# Altium schematic sheet Sheet1.SchDoc
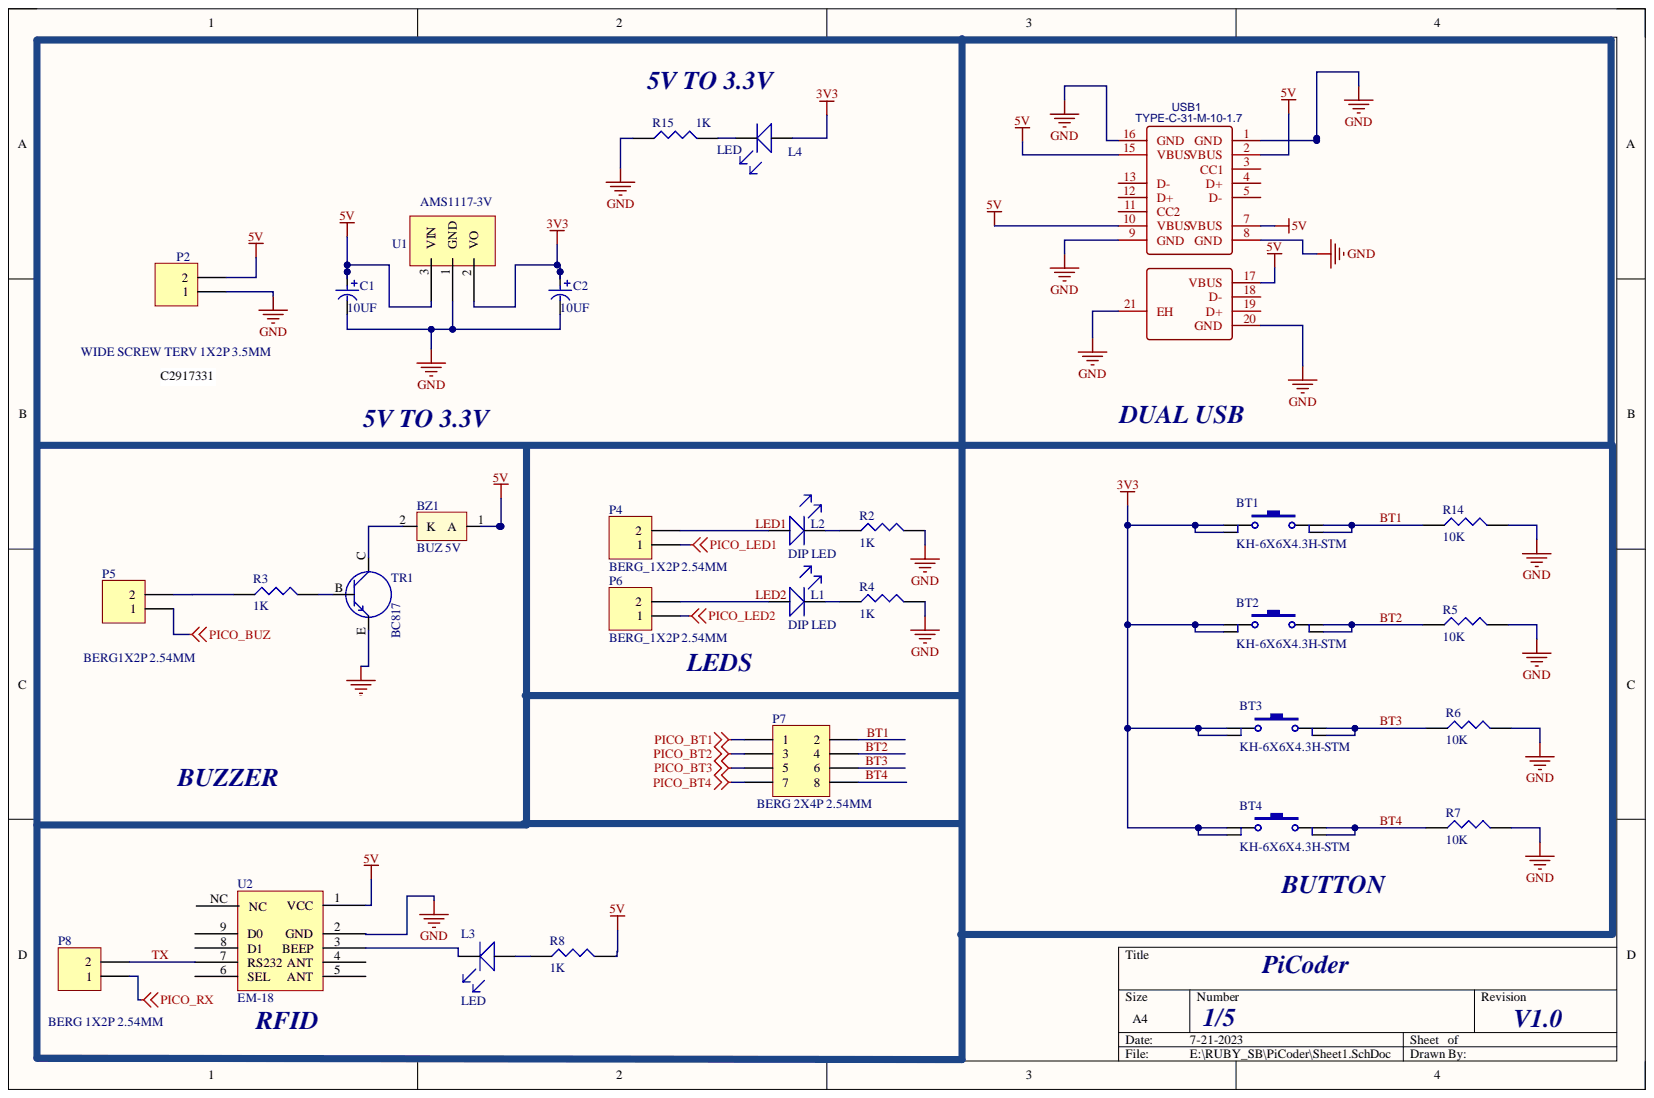
[diagram p0: Sheet1.SchDoc]

## Parts
BT1  KH-6X6X4.3H-STM  [SW_SMD_6X6MM]
BT2  KH-6X6X4.3H-STM  [SW_SMD_6X6MM]
BT3  KH-6X6X4.3H-STM  [SW_SMD_6X6MM]
BT4  KH-6X6X4.3H-STM  [SW_SMD_6X6MM]
BZ1  BUZ 5V  [Buzzer]
C1  10UF  [tantlum caseA]
C2  10UF  [tantlum caseA]
L1  DIP LED  [LED_TH_3MM]
L2  DIP LED  [LED_TH_3MM]
L3  LED  [LED_0603]
L4  LED  [LED_0603]
P2  WIDE SCREW TERV 1X2P 3.5MM  [ST_SCREW 3.5MM 2P]
P4  BERG_1X2P 2.54MM  [2P_2.54MM]
P5  BERG1X2P 2.54MM  [2P_2.54MM]
P6  BERG_1X2P 2.54MM  [2P_2.54MM]
P7  BERG 2X4P 2.54MM  [2X4P 2.54MM]
P8  BERG 1X2P 2.54MM  [2P_2.54MM]
R2  1K  [R_0603]
R3  1K  [R_0603]
R4  1K  [R_0603]
R5  10K  [R_0603]
R6  10K  [R_0603]
R7  10K  [R_0603]
R8  1K  [R_0603]
R14  10K  [R_0603]
R15  1K  [R_0603]
TR1  BC817  [SOT23]
U1  AMS1117-3V  [AMS1117_3V3]
U2  EM-18  [RFID]
USB1  TYPE-C-31-M-10-1.7  [USBA  AND USBC]

## Nets
3V3: BT1.1 BT1.2 BT2.1 BT2.2 BT3.1 BT3.2 BT4.1 BT4.2 C2.1 L4.A U1.2
5V: BZ1.1 C1.1 P2.2 R8.2 U1.3 U2.1(VCC) USB1.2(VBUS) USB1.7(VBUS) USB1.10(VBUS) USB1.15(VBUS) USB1.17(VBUS)
GND: C1.2 C2.2 P2.1 R2.2 R4.2 R5.2 R6.2 R7.2 R14.2 R15.1 TR1.E U1.1 U2.2(GND) USB1.1(GND) USB1.8(GND) USB1.9(GND) USB1.16(GND) USB1.20(GND) USB1.21(EH)
PICO_BT1: P7.1
PICO_BT2: P7.3
PICO_BT3: P7.5
PICO_BT4: P7.7
PICO_BUZ: P5.1
PICO_LED1: P4.1
PICO_LED2: P6.1
PICO_RX: P8.1
BT1: BT1.3 BT1.4 P7.2 R14.1
BT2: BT2.3 BT2.4 P7.4 R5.1
BT3: BT3.3 BT3.4 P7.6 R6.1
BT4: BT4.3 BT4.4 P7.8 R7.1
LED1: L2.A P4.2
LED2: L1.A P6.2
TX: P8.2 U2.7(RS232)
NetBZ1_2: BZ1.2 TR1.C
NetL1_K: L1.K R4.1
NetL2_K: L2.K R2.1
NetL3_A: L3.A R8.1
NetL3_K: L3.K U2.3(BEEP)
NetL4_K: L4.K R15.2
NetP5_2: P5.2 R3.1
NetR3_2: R3.2 TR1.B

Not connected: U2 4(ANT) 5(ANT) 6(SEL) 8(D1) 9(D0) NC; USB1 3(CC1) 4(D+) 5(D-) 11(CC2) 12(D+) 13(D-) 18(D-) 19(D+)

## Library data of the parts
BT1-BT4 = TACTILE_SWITCH: Component Kind: Standard; Library Name: dxp_pasl_lib.IntLib
BZ1 = Buzzer: Component Kind: Standard; Library Name: dxp_pasl_lib.IntLib
C1 C2 = CAP_ELE: Component Kind: Standard; Library Name: MIX.SchLib
L1 L2 = LED: Component Kind: Standard; Library Name: dxp_pasl_lib.IntLib
P2 P4-P6 P8 = Header 2: Header, 2-Pin; Component Kind: Standard; LatestRevisionDate: 17-Jul-2002; LatestRevisionNote: Re-released for DXP Platform.; Library Name: Miscellaneous Connectors.IntLib; Signal Integrity: Connector; Publisher: Altium Limited
P7 = Header 4X2: Header, 4-Pin, Dual row; Component Kind: Standard; LatestRevisionDate: 17-Jul-2002; LatestRevisionNote: Re-released for DXP Platform.; Library Name: Miscellaneous Connectors.IntLib; Signal Integrity: Connector; Publisher: Altium Limited
R2-R8 R14 R15 = RES: Component Kind: Standard; Library Name: dxp_pasl_lib.IntLib
TR1 = TRANSISTER_NPN: Component Kind: Standard; Library Name: dxp_pasl_lib.IntLib
U1 = AMS1117: Component Kind: Standard; Library Name: dxp_pasl_lib.IntLib
U2 = EM-18 RFID: 8MHz XO (Standard) CMOS Oscillator 3.3V Standby (Power Down) 4-SMD, No Lead; Component Kind: Standard; Library Name: dxp_pasl_lib.IntLib
USB1 = TYPE-C-31-M-10: BOM_Manufacturer: Korean Hroparts Elec; Contributor: LCSC; BOM_JLCPCB Part Class: Extended Part; BOM_Manufacturer Part: TYPE-C-31-M-10; BOM_Supplier: LCSC; BOM_Supplier Part: C223904; Component Kind: Standard; spicePre: U; spiceSymbolName: TYPE-C-31-M-10
L3 L4 = LED: Component Kind: Standard; Library Name: dxp_pasl_lib.IntLib
